# Altium schematic sheet IMU_MPU9250.SchDoc
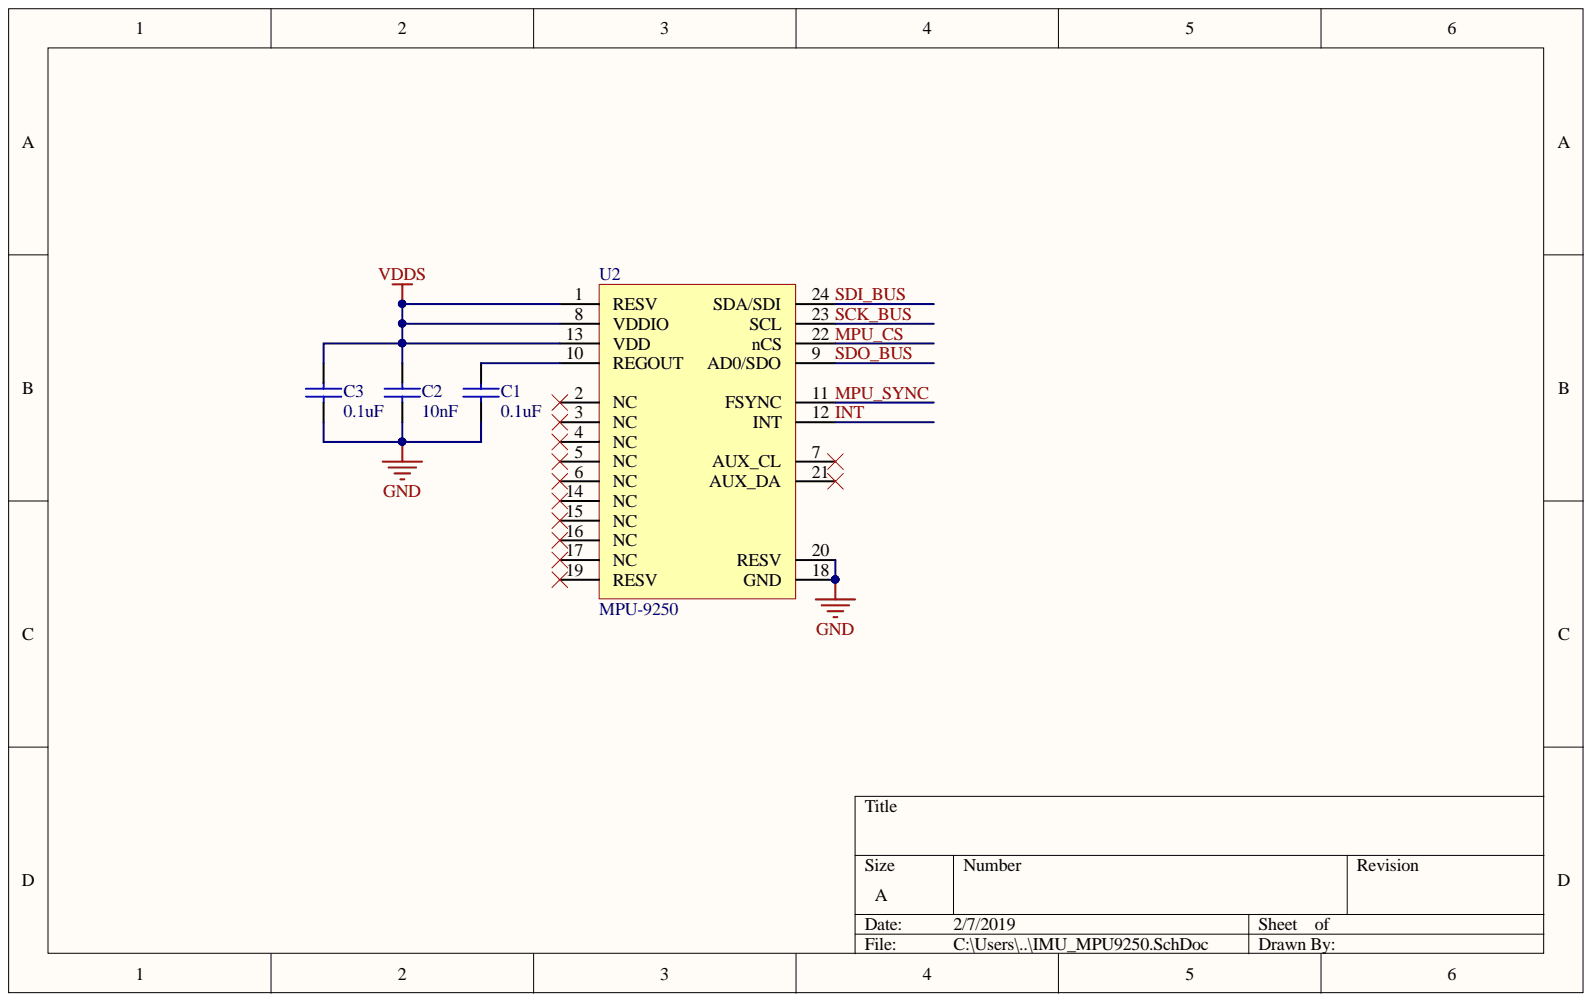
[diagram p0: IMU_MPU9250.SchDoc]

## Parts
C1  0.1uF  [0402[IMP]]
C2  10nF  [0402[IMP]]
C3  0.1uF  [0402[IMP]]
U2  MPU-9250

## Nets
GND: C1.2 C2.2 C3.2 U2.18(GND) U2.20(RESV)
VDDS: C2.1 C3.1 U2.1(RESV) U2.8(VDDIO) U2.13(VDD)
INT: U2.12(INT)
MPU_CS: U2.22(nCS)
MPU_SYNC: U2.11(FSYNC)
SCK_BUS: U2.23(SCL)
SDI_BUS: U2.24(SDA/SDI)
SDO_BUS: U2.9(AD0/SDO)
NetC1_1: C1.1 U2.10(REGOUT)

Not connected: U2 2(NC) 3(NC) 4(NC) 5(NC) 6(NC) 7(AUX_CL) 14(NC) 15(NC) 16(NC) 17(NC) 19(RESV) 21(AUX_DA)

## Library data of the parts
C1-C3 = CAPACITOR: CLASS: DISCRETE; CURRENT: CIMAX; DIST: FLAT; KNEE: CBMAX; MAX_TEMP: CTMAX; PSpiceTemplate: C^@REFDES %1 %2 ?TOLERANCE|C^@REFDES| @VALUE ?IC/IC=@IC/ TC=@TC1,@TC2 ?TOLERANCE|\n.model C^@REFDES CAP C=1 DEV=@TOLERANCE%|; SLOPE: CSMAX; TC1: 0; VOLTAGE: CMAX; Component Kind: Standard; Simulation: CAPACITOR; TC2: 0; Library Name: Biosense_Altium_Library.IntLib
  C1 C3: Value: 0.1uF
  C2: Value: 10nF
U2 = MPU-9250: Component Kind: Standard; Library Name: Biosense_Altium_Library.IntLib
